# Altium schematic sheet DigitalLoad.SchDoc
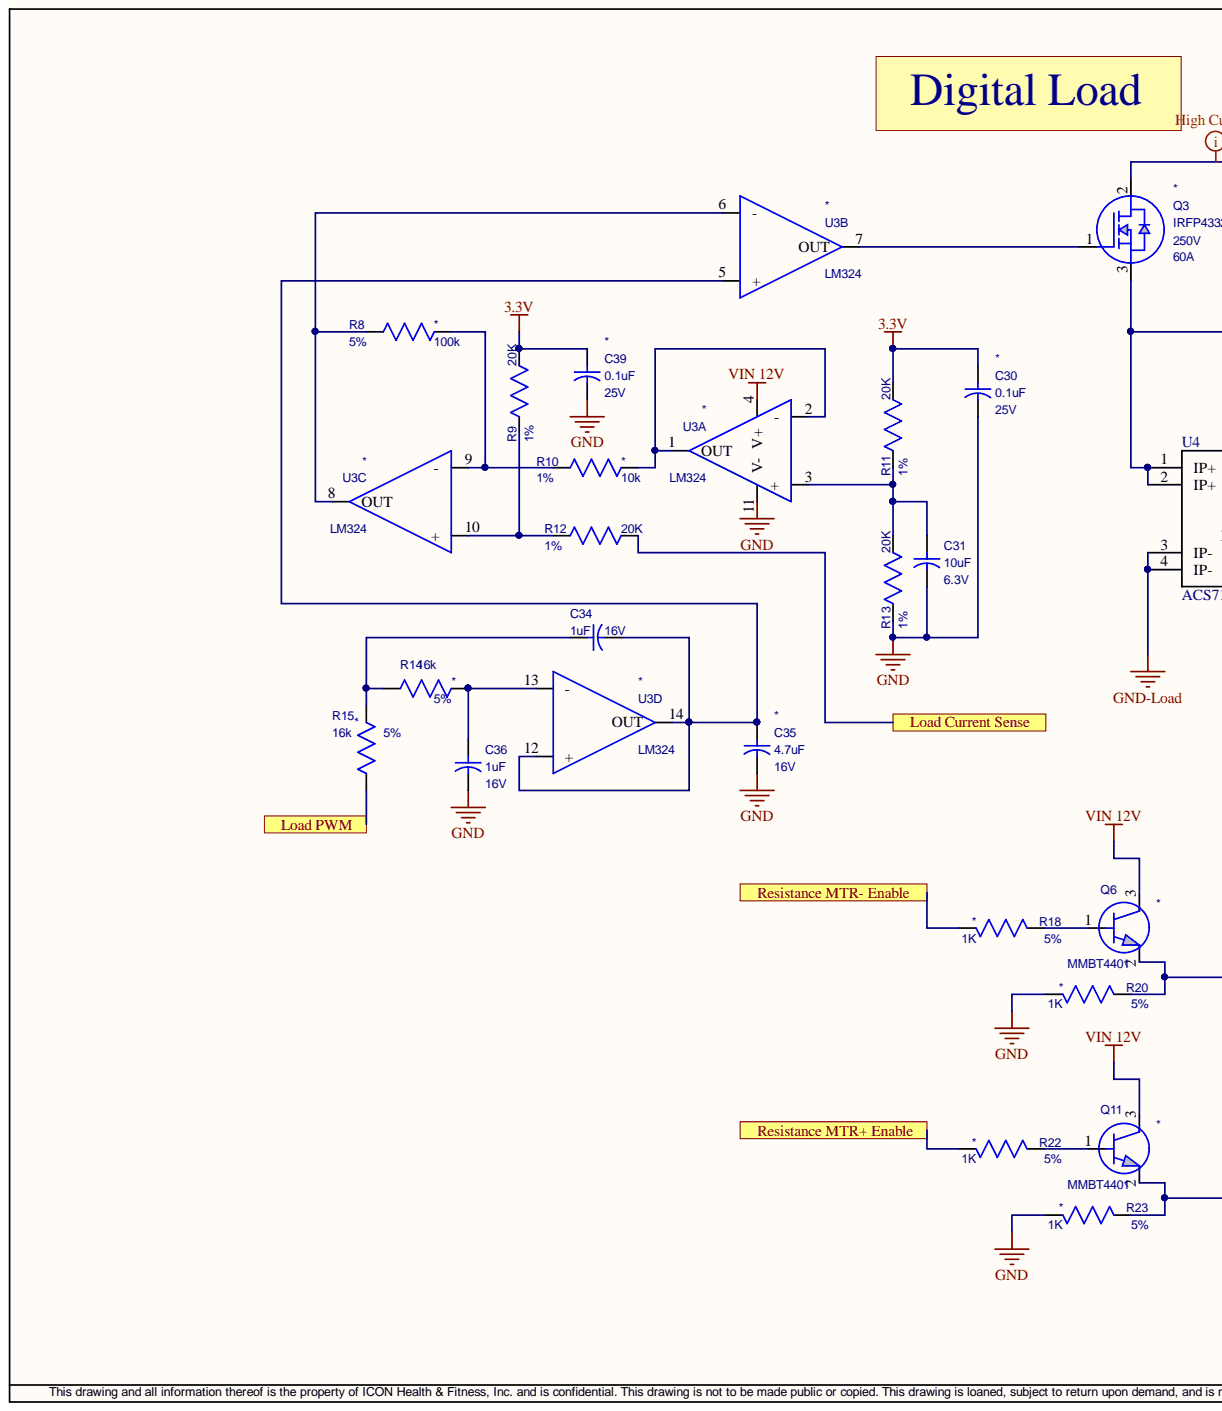
[diagram p0: DigitalLoad.SchDoc, left]
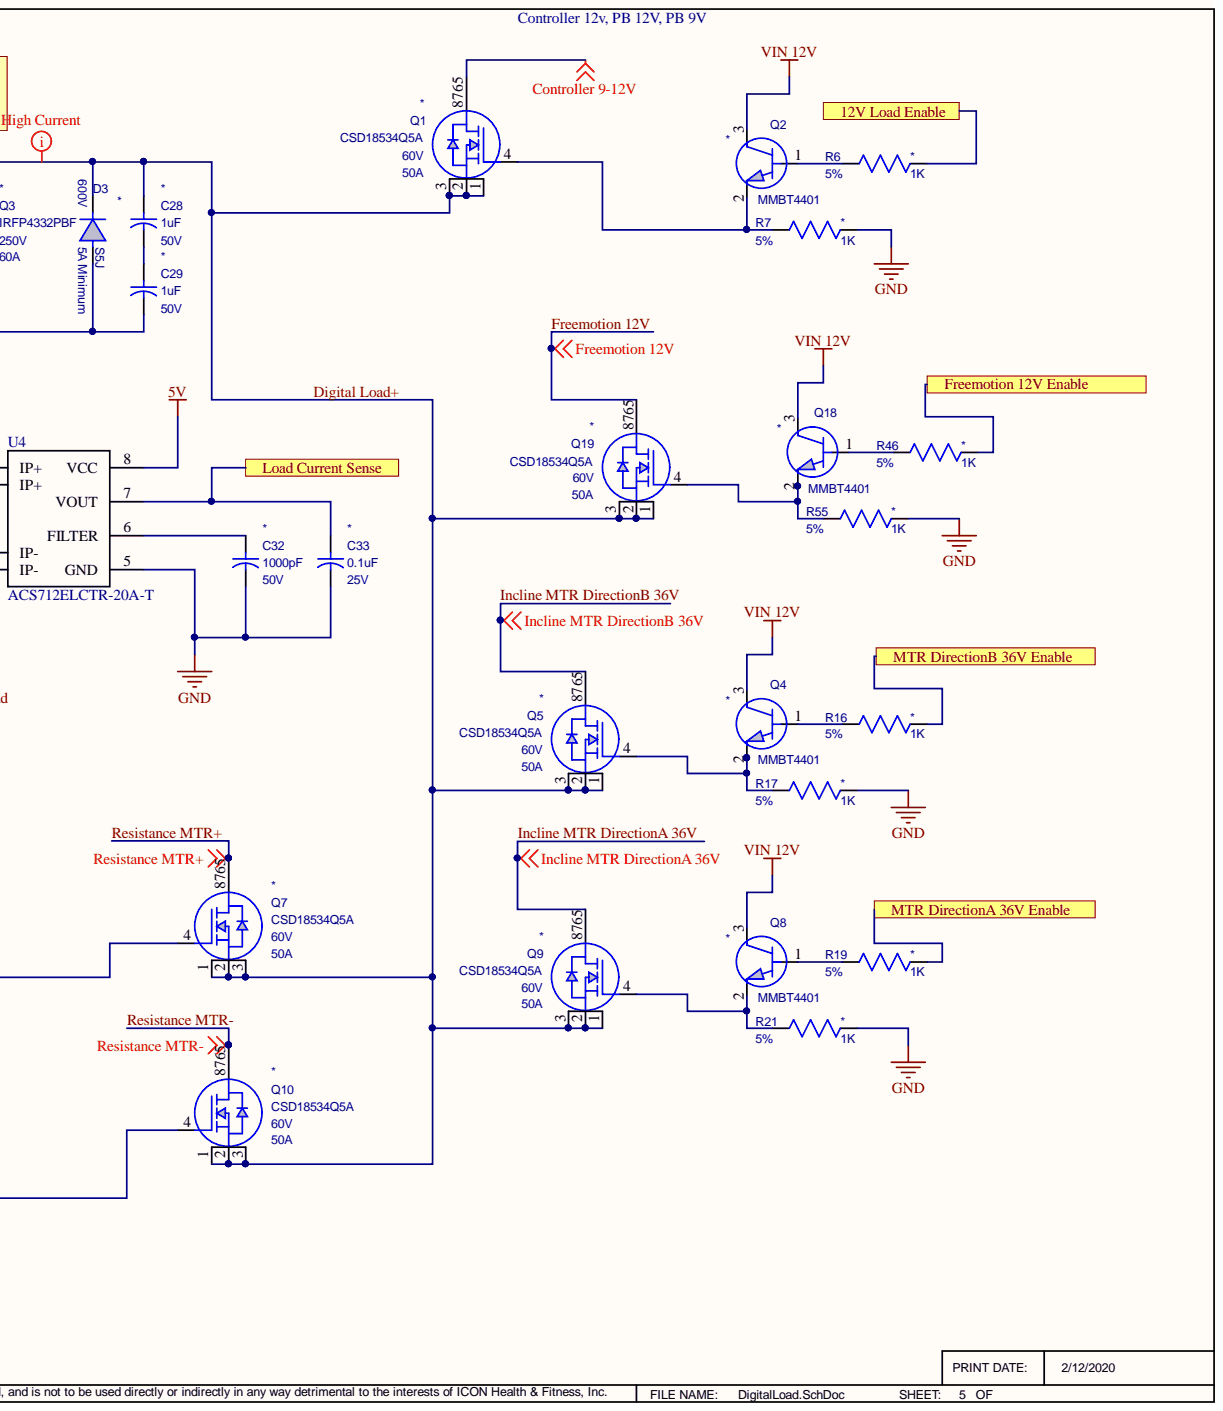
[diagram p1: DigitalLoad.SchDoc, right]

## Parts
C28  value 1uF  Voltage: 50V  [0603]  (hidden comment: CAP_NON_POLAR_SMT)
C29  value 1uF  Voltage: 50V  [0603]  (hidden comment: CAP_NON_POLAR_SMT)
C30  value 0.1uF  Voltage: 25V  [0402]  (hidden comment: CAP_NON_POLAR_SMT)
C31  value 10uF  Voltage: 6.3V  [0402]  (hidden comment: 10uF_0402)
C32  value 1000pF  Voltage: 50V  [0402]  (hidden comment: CAP_NON_POLAR_SMT)
C33  value 0.1uF  Voltage: 25V  [0402]  (hidden comment: CAP_NON_POLAR_SMT)
C34  value 1uF  Voltage: 16V  [0402]  (hidden comment: 1uF_0402)
C35  value 4.7uF  Voltage: 16V  [0603]  (hidden comment: CAP_NON_POLAR_SMT)
C36  value 1uF  Voltage: 16V  [0402]  (hidden comment: 1uF_0402)
C39  value 0.1uF  Voltage: 25V  [0402]  (hidden comment: CAP_NON_POLAR_SMT)
D3  value S5J  Amperage: 5A Minimum  Voltage: 600V  [SMC]  (hidden comment: DIODE_SMT)
Q1  value CSD18534Q5A  Amperage: 50A  Voltage: 60V  [8-POWERTDFN]  (hidden comment: MOSFET_N_CHANNEL_W_DIODE_8POWERTDFN)
Q2  value MMBT4401  [SOT23-3]  (hidden comment: TRANSISTOR_NPN_SOT23)
Q3  value IRFP4332PBF  Amperage: 60A  Voltage: 250V  [TO-247_3PIN]  (hidden comment: MOSFET_N_CHANNEL_W_DIODE)
Q4  value MMBT4401  [SOT23-3]  (hidden comment: TRANSISTOR_NPN_SOT23)
Q5  value CSD18534Q5A  Amperage: 50A  Voltage: 60V  [8-POWERTDFN]  (hidden comment: MOSFET_N_CHANNEL_W_DIODE_8POWERTDFN)
Q6  value MMBT4401  [SOT23-3]  (hidden comment: TRANSISTOR_NPN_SOT23)
Q7  value CSD18534Q5A  Amperage: 50A  Voltage: 60V  [8-POWERTDFN]  (hidden comment: MOSFET_N_CHANNEL_W_DIODE_8POWERTDFN)
Q8  value MMBT4401  [SOT23-3]  (hidden comment: TRANSISTOR_NPN_SOT23)
Q9  value CSD18534Q5A  Amperage: 50A  Voltage: 60V  [8-POWERTDFN]  (hidden comment: MOSFET_N_CHANNEL_W_DIODE_8POWERTDFN)
Q10  value CSD18534Q5A  Amperage: 50A  Voltage: 60V  [8-POWERTDFN]  (hidden comment: MOSFET_N_CHANNEL_W_DIODE_8POWERTDFN)
Q11  value MMBT4401  [SOT23-3]  (hidden comment: TRANSISTOR_NPN_SOT23)
Q18  value MMBT4401  [SOT23-3]  (hidden comment: TRANSISTOR_NPN_SOT23)
Q19  value CSD18534Q5A  Amperage: 50A  Voltage: 60V  [8-POWERTDFN]  (hidden comment: MOSFET_N_CHANNEL_W_DIODE_8POWERTDFN)
R6  value 1K  Tolerance: 5%  [0402]  (hidden comment: RESISTOR_SMT_5%)
R7  value 1K  Tolerance: 5%  [0402]  (hidden comment: RESISTOR_SMT_5%)
R8  value 100k  Tolerance: 5%  [0402]  (hidden comment: RESISTOR_SMT_5%)
R9  value 20K  Tolerance: 1%  [R0402]  (hidden comment: Resistor_0402_1%)
R10  value 10k  Tolerance: 1%  [0402]  (hidden comment: RESISTOR_SMT_1%)
R11  value 20K  Tolerance: 1%  [R0402]  (hidden comment: Resistor_0402_1%)
R12  value 20K  Tolerance: 1%  [R0402]  (hidden comment: Resistor_0402_1%)
R13  value 20K  Tolerance: 1%  [R0402]  (hidden comment: Resistor_0402_1%)
R14  value 16k  Tolerance: 5%  [0402]  (hidden comment: RESISTOR_SMT_5%)
R15  value 16k  Tolerance: 5%  [0402]  (hidden comment: RESISTOR_SMT_5%)
R16  value 1K  Tolerance: 5%  [0402]  (hidden comment: RESISTOR_SMT_5%)
R17  value 1K  Tolerance: 5%  [0402]  (hidden comment: RESISTOR_SMT_5%)
R18  value 1K  Tolerance: 5%  [0402]  (hidden comment: RESISTOR_SMT_5%)
R19  value 1K  Tolerance: 5%  [0402]  (hidden comment: RESISTOR_SMT_5%)
R20  value 1K  Tolerance: 5%  [0402]  (hidden comment: RESISTOR_SMT_5%)
R21  value 1K  Tolerance: 5%  [0402]  (hidden comment: RESISTOR_SMT_5%)
R22  value 1K  Tolerance: 5%  [0402]  (hidden comment: RESISTOR_SMT_5%)
R23  value 1K  Tolerance: 5%  [0402]  (hidden comment: RESISTOR_SMT_5%)
R46  value 1K  Tolerance: 5%  [0402]  (hidden comment: RESISTOR_SMT_5%)
R55  value 1K  Tolerance: 5%  [0402]  (hidden comment: RESISTOR_SMT_5%)
U3  value LM324  [TSSOP-14]  (hidden comment: OPAMP_QUAD_14PIN)  (parts A,B,C,D)
U4  ACS712  value ACS712ELCTR-20A-T  [SOIC8]

## Ports
12V Load Enable, Freemotion 12V Enable, Load Current Sense, Load PWM, MTR DirectionA 36V Enable, MTR DirectionB 36V Enable, Resistance MTR+ Enable, Resistance MTR- Enable

## Nets
3.3V: C30.1 C39.1 R9.1 R11.1
5V: U4.8(VCC)
Controller 9-12V: Q1.8765
GND: C30.2 C31.2 C32.2 C33.2 C35.2 C36.2 C39.2 R7.1 R13.2 R17.1 R20.1 R21.1 R23.1 R55.1 U3.11(V-) U4.5(GND)
GND-Load: U4.3(IP-) U4.4(IP-)
VIN 12V: Q2.3 Q4.3 Q6.3 Q8.3 Q11.3 Q18.3 U3.4(V+)
12V Load Enable: R6.1 ; port 12V Load Enable
Digital Load+: C28.1 D3.1 Q1.1 Q1.2 Q1.3 Q3.2 Q5.1 Q5.2 Q5.3 Q7.1 Q7.2 Q7.3 Q9.1 Q9.2 Q9.3 Q10.1 Q10.2 Q10.3 Q19.1 Q19.2 Q19.3
Freemotion 12V: Q19.8765
Freemotion 12V Enable: R46.1 ; port Freemotion 12V Enable
Incline MTR DirectionA 36V: Q9.8765
Incline MTR DirectionB 36V: Q5.8765
Load Current Sense: C33.1 R12.1 U4.7(VOUT) ; port Load Current Sense
Load PWM: R15.2 ; port Load PWM
MTR DirectionA 36V Enable: R19.1 ; port MTR DirectionA 36V Enable
MTR DirectionB 36V Enable: R16.1 ; port MTR DirectionB 36V Enable
Resistance MTR+: Q7.8765
Resistance MTR-: Q10.8765
NetC28_2: C28.2 C29.1
NetC29_2: C29.2 D3.2 Q3.3 U4.1(IP+) U4.2(IP+)
NetC31_1: C31.1 R11.2 R13.1 U3.3(+)
NetC32_1: C32.1 U4.6(FILTER)
NetC34_1: C34.1 R14.2 R15.1
NetC34_2: C34.2 C35.1 U3.5(+) U3.12(+) U3.14(OUT)
NetC36_1: C36.1 R14.1 U3.13(-)
NetQ1_4: Q1.4 Q2.2 R7.2
NetQ2_1: Q2.1 R6.2
NetQ3_1: Q3.1 U3.7(OUT)
NetQ4_1: Q4.1 R16.2
NetQ4_2: Q4.2 Q5.4 R17.2
NetQ6_1: Q6.1 R18.2
NetQ6_2: Q6.2 Q7.4 R20.2
NetQ8_1: Q8.1 R19.2
NetQ8_2: Q8.2 Q9.4 R21.2
NetQ10_4: Q10.4 Q11.2 R23.2
NetQ11_1: Q11.1 R22.2
NetQ18_1: Q18.1 R46.2
NetQ18_2: Q18.2 Q19.4 R55.2
NetR8_1: R8.1 R10.2 U3.9(-)
NetR8_2: R8.2 U3.6(-) U3.8(OUT)
NetR9_2: R9.2 R12.2 U3.10(+)
NetR10_1: R10.1 U3.1(OUT) U3.2(-)
NetR18_1: R18.1
NetR22_1: R22.1

## Library data of the parts
C28 C29 = 265218: Capacitor, 1uF, 50V, X5R, Ceramic, ±20%, Non-Polar, 0603, TDK: C1608X5R1H105M080 or equivalent; Database Table Name: AltiumComponents; CapType: X5R; Component Kind: Standard; ComponentLink4Description: PDM Parts Maintenance; ComponentLink4URL: https://pdm.iconfitness.com/PDM/EBOM/PartsMaintenance/PartsMaintenance.aspx?Editplidx=265218; ComponentType: Capacitor; Composition: Ceramic; Cost: 0.001; Package: 0603; plIDX: 265218; Polarity: Non-Polar; Tolerance: ±20%; Vendor: TDK: C1608X5R1H105M080 or equivalent; CheckedBy: Sam Rhoufiry; CreatedBy: Mike Tyger; LastUpdatedBy: Mike Tyger; Library Name: bome.DbLib
C30 C33 C39 = 305426: Capacitor, 0.1uF, 25V, X5R, Ceramic, 10%, Non-Polar, 0402, Murata: GRM155R61E104KA87D or equivalent; Database Table Name: AltiumComponents; CapType: X5R; Component Kind: Standard; ComponentLink4Description: PDM Parts Maintenance; ComponentLink4URL: https://pdm.iconfitness.com/PDM/EBOM/PartsMaintenance/PartsMaintenance.aspx?Editplidx=305426; ComponentType: Capacitor; Composition: Ceramic; Cost: 0; Package: 0402; plIDX: 305426; Polarity: Non-Polar; Tolerance: 10%; Vendor: Murata: GRM155R61E104KA87D or equivalent; CheckedBy: Sam Rhoufiry; CreatedBy: Jeremy Hubbard; LastUpdatedBy: Jeremy Hubbard; Library Name: bome.DbLib
C31 = 295732: Capacitor, 10uF, 6.3V, X5R, +/-20%, Non-Polar, 0402, 2, Murata:GRM155R60J106ME47; Database Table Name: AltiumComponents; CapType: X5R; Component Kind: Standard; ComponentLink1Description: Datasheet; ComponentLink4Description: PDM Parts Maintenance; ComponentLink4URL: https://pdm.iconfitness.com/PDM/EBOM/PartsMaintenance/PartsMaintenance.aspx?Editplidx=295732; ComponentType: Capacitor; Cost: 0.05; ModifyDate: 4/13/10; Package: 0402; Pins: 2; plIDX: 295732; Polarity: Non-Polar; Supplier 1: Digi-Key; Tolerance: +/-20%; Vendor: Murata:GRM155R60J106ME47; CheckedBy: gdeguzman; CreatedBy: Brian Beardall; LastUpdatedBy: Steven Zhou; Library Name: bome.DbLib
C32 = 284146: Capacitor, 1000pF, 50V, NPO, Ceramic, ±5%, Non-Polar, 0402, Murata: GRM1555C1H102JA01D or equivalent; Database Table Name: AltiumComponents; CapType: NPO; Component Kind: Standard; ComponentLink1Description: Datasheet; ComponentLink1URL: \\iconfitness.com\loganfiles\RND Departments\electronics\accel_lib\datasheets\c02e.pdf; ComponentLink4Description: PDM Parts Maintenance; ComponentLink4URL: https://pdm.iconfitness.com/PDM/EBOM/PartsMaintenance/PartsMaintenance.aspx?Editplidx=284146; ComponentType: Capacitor; Composition: Ceramic; Cost: 0.005; Package: 0402; plIDX: 284146; Polarity: Non-Polar; Tolerance: ±5%; Vendor: Murata: GRM1555C1H102JA01D or equivalent; CheckedBy: Sam Rhoufiry; CreatedBy: Mourly Thov; LastUpdatedBy: Mike Tyger; Library Name: bome.DbLib
C34 C36 = 295904: Capacitor, 1uF, 16V, Monolithic, +/- 5%, Non-Polar, 0402, 2; Database Table Name: AltiumComponents; Tolerance: +/- 5%; CapType: Monolithic; Component Kind: Standard; ComponentLink1Description: Datasheet; ComponentLink4Description: PDM Parts Maintenance; ComponentLink4URL: https://pdm.iconfitness.com/PDM/EBOM/PartsMaintenance/PartsMaintenance.aspx?Editplidx=295904; ComponentType: Capacitor; Cost: 0.03; ModifyDate: 4/13/10; Package: 0402; Pins: 2; plIDX: 295904; Polarity: Non-Polar; CheckedBy: gdeguzman; CreatedBy: Brian Beardall; LastUpdatedBy: Brian Beardall; Library Name: bome.DbLib
C35 = 264099: Capacitor, 4.7uF, 16V, X5R, Ceramic, +/- 20%, Non-Polar, 0603, 2, TDK: C1608X5R1C475M080AC or equivalent; Database Table Name: AltiumComponents; Tolerance: +/- 20%; CapType: X5R; Component Kind: Standard; ComponentLink1Description: Datasheet; ComponentLink1URL: \\iconfitness.com\loganfiles\RND Departments\electronics\accel_lib\datasheets\4.7uF.pdf; ComponentLink4Description: PDM Parts Maintenance; ComponentLink4URL: https://pdm.iconfitness.com/PDM/EBOM/PartsMaintenance/PartsMaintenance.aspx?Editplidx=264099; ComponentType: Capacitor; Composition: Ceramic; Cost: 0.04; Package: 0603; Pins: 2; plIDX: 264099; Polarity: Non-Polar; Vendor: TDK: C1608X5R1C475M080AC or equivalent; CheckedBy: Sam Rhoufiry; CreatedBy: Mourly Thov; LastUpdatedBy: Mike Tyger; Library Name: bome.DbLib
D3 = 343133: Diode, S5J, Rectifier, 600V, 5A Minimum, DO-214AB(SMC), Taiwan Semiconductor: S5J, SMC: S5JC or Equivalent; Database Table Name: AltiumComponents; Component Kind: Standard; ComponentLink4Description: PDM Parts Maintenance; ComponentLink4URL: https://pdm.iconfitness.com/PDM/EBOM/PartsMaintenance/PartsMaintenance.aspx?Editplidx=343133; ComponentType: Diode; Cost: 0; DiodeType: Rectifier; Package: DO-214AB(SMC); plIDX: 343133; Vendor: Taiwan Semiconductor: S5J, SMC: S5JC or Equivalent; CheckedBy: gdeguzman; CreatedBy: Mike Tyger; LastUpdatedBy: Mike Tyger; Library Name: bome.DbLib
Q1 Q5 Q7 Q9 Q10 Q19 = 353487: Transistor, CSD18534Q5A, 60V, 8-VSONP, 50A, 8 Pin, N-Channel MOSFET, TI: CSD18534Q5A; Database Table Name: AltiumComponents; Component Kind: Standard; ComponentLink4Description: PDM Parts Maintenance; ComponentLink4URL: https://pdm.iconfitness.com/PDM/EBOM/PartsMaintenance/PartsMaintenance.aspx?Editplidx=353487; ComponentType: Transistor; Cost: 0.2; Package: 8-VSONP; Pins: 8 Pin; plIDX: 353487; TransistorType: N-Channel MOSFET; Vendor: TI: CSD18534Q5A; CreatedBy: Rui Zhang; LastUpdatedBy: Mike Tyger; Library Name: bome.DbLib
Q2 Q4 Q6 Q8 Q11 Q18 = 265442: Transistor, MMBT4401, 40V, SOT23-3, 500mA, NPN, Transistor, On Semi: MMBT4401LT1; Database Table Name: AltiumComponents; Amperage: 500mA; ChipType: Transistor; Component Kind: Standard; ComponentLink4Description: PDM Parts Maintenance; ComponentLink4URL: https://pdm.iconfitness.com/PDM/EBOM/PartsMaintenance/PartsMaintenance.aspx?Editplidx=265442; ComponentType: Transistor; Cost: 0.0094; Package: SOT23-3; plIDX: 265442; TransistorType: NPN; Vendor: On Semi: MMBT4401LT1; Voltage: 40V; CheckedBy: gdeguzman; CreatedBy: Mike Tyger; LastUpdatedBy: Mike Tyger; Library Name: bome.DbLib
Q3 = 20564: Transistor, IRFP4332PBF, 250V, TO-247, 60A, MOSFET, International Rectifier: IRFP4332PBF; Database Table Name: AltiumComponents; Component Kind: Standard; ComponentLink4Description: PDM Parts Maintenance; ComponentLink4URL: https://pdm.iconfitness.com/PDM/EBOM/PartsMaintenance/PartsMaintenance.aspx?Editplidx=20564; ComponentType: Transistor; Cost: 0.85; Package: TO-247; plIDX: 20564; TransistorType: MOSFET; Vendor: International Rectifier: IRFP4332PBF; LastUpdatedBy: Mike Tyger; Library Name: bome.DbLib
R6 R7 R16-R23 R46 R55 = 22012: Resistor, 1K, ThickFilm, 5%, 0402, 1/10W, Any; Database Table Name: AltiumComponents; Component Kind: Standard; ComponentLink4Description: PDM Parts Maintenance; ComponentLink4URL: https://pdm.iconfitness.com/PDM/EBOM/PartsMaintenance/PartsMaintenance.aspx?Editplidx=22012; ComponentType: Resistor; Composition: ThickFilm; Cost: 0.001; Package: 0402; plIDX: 22012; Vendor: Any; Wattage: 1/10W; CheckedBy: gdeguzman; LastUpdatedBy: Mike Tyger; Library Name: bome.DbLib
R8 = 19598: Resistor, 100k, ThickFilm, 5%, 0402, 1/10W, Any; Database Table Name: AltiumComponents; Component Kind: Standard; ComponentLink4Description: PDM Parts Maintenance; ComponentLink4URL: https://pdm.iconfitness.com/PDM/EBOM/PartsMaintenance/PartsMaintenance.aspx?Editplidx=19598; ComponentType: Resistor; Composition: ThickFilm; Cost: 0.0004; Package: 0402; plIDX: 19598; Vendor: Any; Wattage: 1/10W; CheckedBy: gdeguzman; LastUpdatedBy: Mike Tyger; Library Name: bome.DbLib
R9 R11-R13 = 362809: Resistor, 20K, ThickFilm, 1%, 0402, 1/10W, 2, Any; Database Table Name: AltiumComponents; Component Kind: Standard; ComponentLink4Description: PDM Parts Maintenance; ComponentLink4URL: https://pdm.iconfitness.com/PDM/EBOM/PartsMaintenance/PartsMaintenance.aspx?Editplidx=362809; ComponentType: Resistor; Composition: ThickFilm; Cost: 0; ModifyDate: 4/13/10; Package: 0402; Pins: 2; plIDX: 362809; Vendor: Any; Wattage: 1/10W; CheckedBy: gdeguzman; CreatedBy: Steven Zhou; LastUpdatedBy: Steven Zhou; Library Name: bome.DbLib
R10 = 302873: Resistor, 10k, ThickFilm, 1%, 0402, 1/10W, Any; Database Table Name: AltiumComponents; Component Kind: Standard; ComponentLink4Description: PDM Parts Maintenance; ComponentLink4URL: https://pdm.iconfitness.com/PDM/EBOM/PartsMaintenance/PartsMaintenance.aspx?Editplidx=302873; ComponentType: Resistor; Composition: ThickFilm; Cost: 0; Package: 0402; plIDX: 302873; Vendor: Any; Wattage: 1/10W; CheckedBy: gdeguzman; CreatedBy: Sara Lin; LastUpdatedBy: Mike Tyger; Library Name: bome.DbLib
R14 R15 = 365391: Resistor, 16k, ThickFilm, 5%, 0402, 1/10W, Any; Database Table Name: AltiumComponents; Component Kind: Standard; ComponentLink4Description: PDM Parts Maintenance; ComponentLink4URL: https://pdm.iconfitness.com/PDM/EBOM/PartsMaintenance/PartsMaintenance.aspx?Editplidx=365391; ComponentType: Resistor; Composition: ThickFilm; Cost: 0; Package: 0402; plIDX: 365391; Vendor: Any; Wattage: 1/10W; CheckedBy: gdeguzman; CreatedBy: Ross Bunnell; LastUpdatedBy: Ross Bunnell; Library Name: bome.DbLib
U3 = 296888: IC, LM324, Op-Amp, TSSOP-14, 14 Pins, TI: LM324PWR or Equivalent; Database Table Name: AltiumComponents; ChipType: Op-Amp; Component Kind: Standard; ComponentLink4Description: PDM Parts Maintenance; ComponentLink4URL: https://pdm.iconfitness.com/PDM/EBOM/PartsMaintenance/PartsMaintenance.aspx?Editplidx=296888; ComponentType: IC; Cost: 0.0602; Package: TSSOP-14; Pins: 14; plIDX: 296888; Vendor: TI: LM324PWR or Equivalent; CheckedBy: gdeguzman; CreatedBy: Mike Tyger; LastUpdatedBy: Mike Tyger; Library Name: bome.DbLib
U4 = 22672: IC, ACS712ELCTR-20A-T, Current Sense, SOIC-8, 8 Pins, Allegro: ACS712ELCTR-20A-T; Database Table Name: AltiumComponents; ChipType: Current Sense; Component Kind: Standard; ComponentLink1Description: Datasheet; ComponentLink1URL: \\iconfitness.com\loganfiles\RND Departments\PDM\Altium\Libarary\_Datasheets\ACS712-Datasheet.pdf; ComponentLink4Description: PDM Parts Maintenance; ComponentLink4URL: https://pdm.iconfitness.com/PDM/EBOM/PartsMaintenance/PartsMaintenance.aspx?Editplidx=22672; ComponentType: IC; Cost: 0.591; Package: SOIC-8; Pins: 8; plIDX: 22672; Vendor: Allegro: ACS712ELCTR-20A-T; LastUpdatedBy: Mike Tyger; Library Name: bome.DbLib
